# Altium schematic sheet LED.SchDoc(LED)
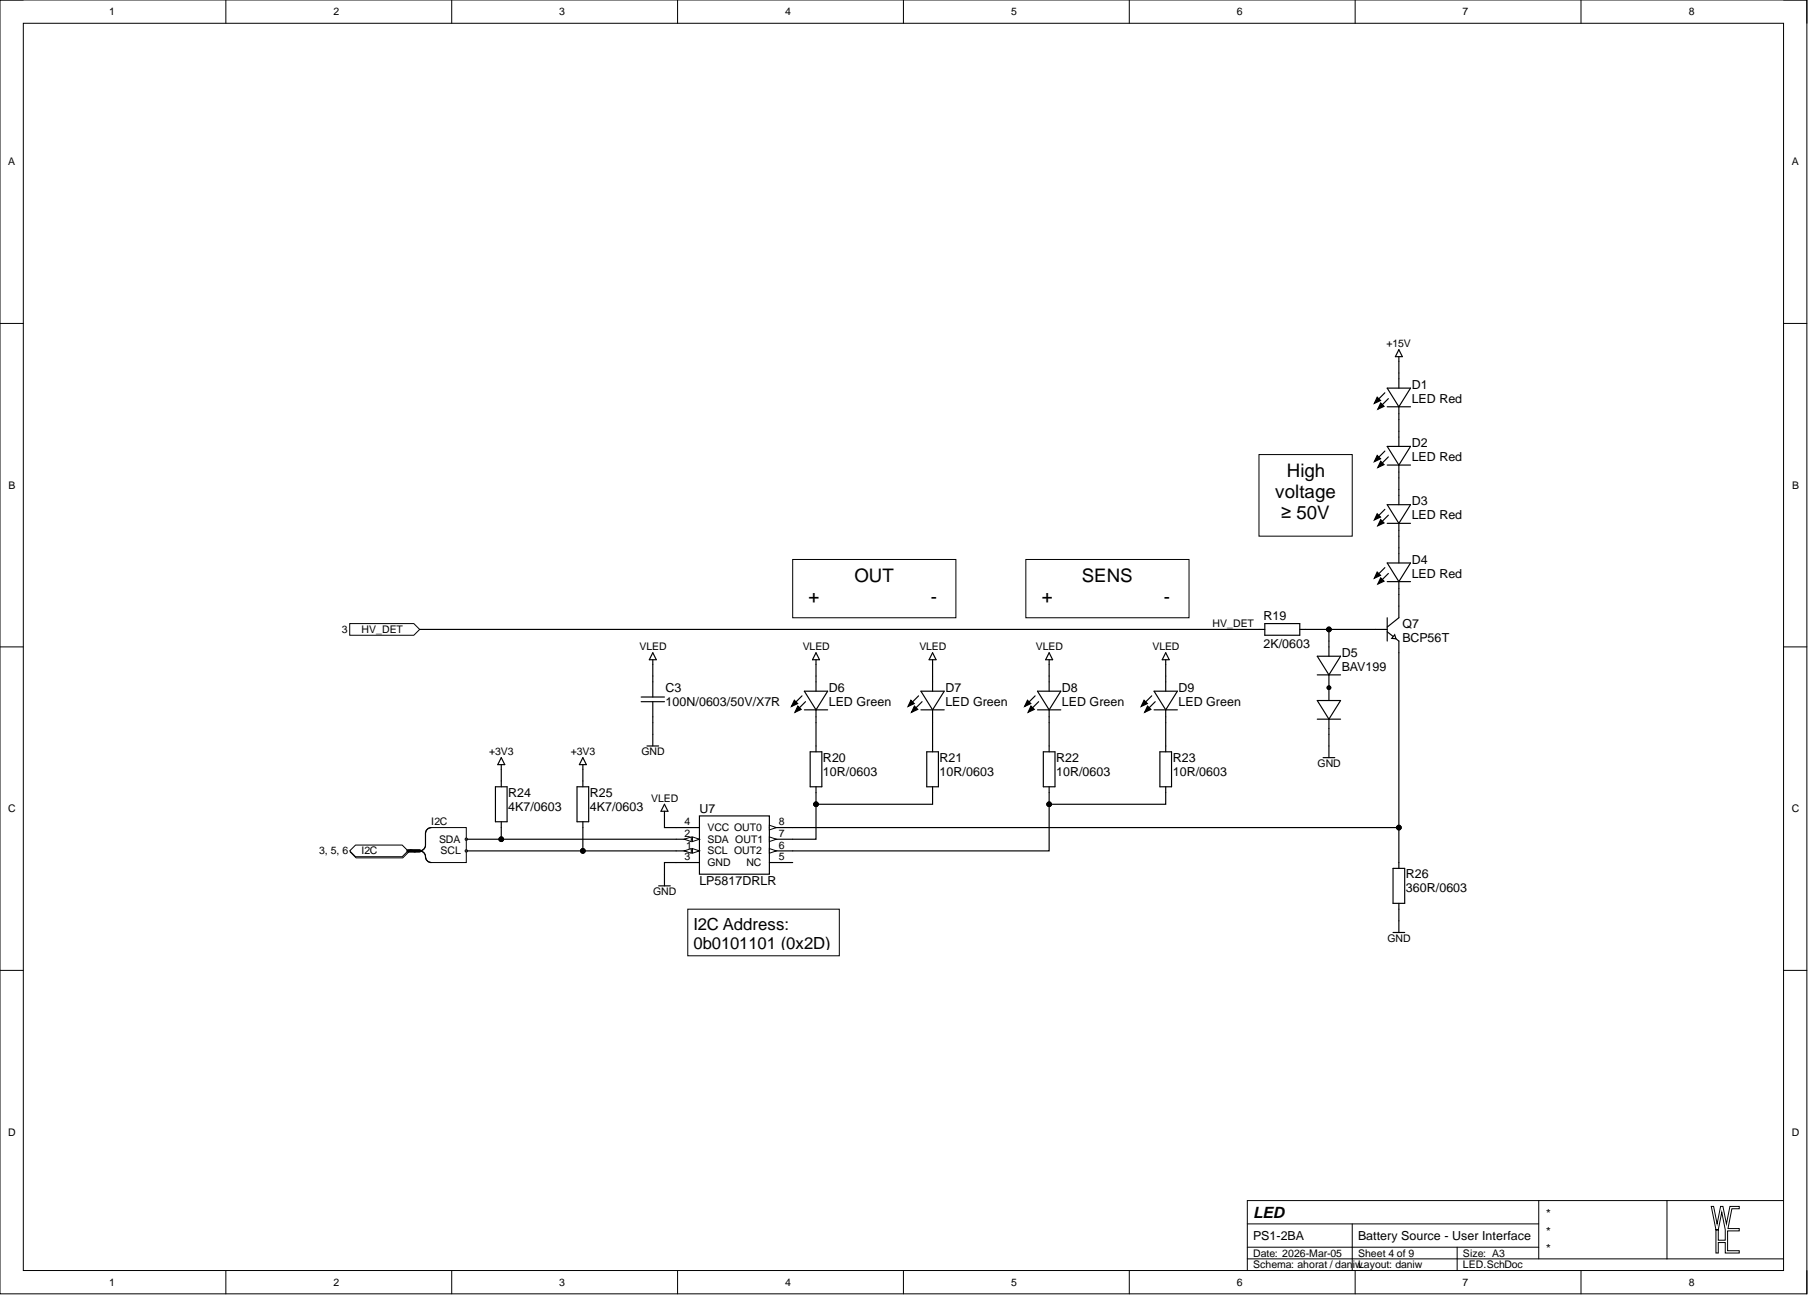
[diagram p0: LED.SchDoc]

## Parts
C3  100N/0603/50V/X7R  [CAP0603_X7R]
D1  LED Red  value Red  Absolute Value: Red  [LED0805_RED]
D2  LED Red  value Red  Absolute Value: Red  [LED0805_RED]
D3  LED Red  value Red  Absolute Value: Red  [LED0805_RED]
D4  LED Red  value Red  Absolute Value: Red  [LED0805_RED]
D5  BAV199  [SOT2395P280X125-3-SE]
D6  LED Green  [LED0805_GREEN]
D7  LED Green  value Green  Absolute Value: Green  [LED0805_GREEN]
D8  LED Green  value Green  Absolute Value: Green  [LED0805_GREEN]
D9  LED Green  value Green  Absolute Value: Green  [LED0805_GREEN]
Q7  BCP56T  [SOT223-230P670X180-4-SW]
R19  2K/0603  [R0603-0.40-WW]
R20  10R/0603  [R0603-0.40-WW]
R21  10R/0603  [R0603-0.40-WW]
R22  10R/0603  [R0603-0.40-WW]
R23  10R/0603  [R0603-0.40-WW]
R24  4K7/0603  [R0603-0.40-WW]
R25  4K7/0603  [R0603-0.40-WW]
R26  360R/0603  [R0603-0.40-WW]
U7  LP5817DRLR  [SOT50P210X60-8-SE]

## Ports
HV_DET, I2C, I2C.SCL, I2C.SDA

## Nets
+3V3: R24.1 R25.1
+15V: D1.2
GND: C3.2 D5.2 R26.2 U7.3(GND)
VLED: C3.1 D6.2 D7.2 D8.2 D9.2 U7.4(VCC)
HV_DET: R19.1 ; port HV_DET
NetD1_1: D1.1 D2.2
NetD2_1: D2.1 D3.2
NetD3_1: D3.1 D4.2
NetD4_1: D4.1 Q7.2,4
NetD5_1: D5.1 Q7.1 R19.2
NetD5_3: D5.3
NetD6_1: D6.1 R20.1
NetD7_1: D7.1 R21.1
NetD8_1: D8.1 R22.1
NetD9_1: D9.1 R23.1
NetQ7_3: Q7.3 R26.1 U7.8(OUT0)
NetR20_2: R20.2 R21.2 U7.7(OUT1)
NetR22_2: R22.2 R23.2 U7.6(OUT2)
NetR24_2: R24.2 U7.2(SDA) ; port I2C
NetR25_2: R25.2 U7.1(SCL) ; port I2C
NetU7_5: U7.5(NC)

## Library data of the parts
C3 = 100N/0603/50V/X7R: CAP SM 0603 100nF 50V X7R; Manufacturer: GENERIC; Manufacturer 1: YAGEO; Manufacturer Part Number 1: CC0603KPX7R9BB104; Absolute Value: 1E-7; Database Table Name: C$; Eurocircuits GPN: GPC0603104; Component Kind: Standard; HelpURL: https://www.yageo.com/upload/media/product/app/datasheet/mlcc/upy-gphc_x7r_6_3v-to-250v.pdf; JLC Type: Basic; Manufacturer 2: YAGEO; Manufacturer Part Number 2: CC0603MRX7R9BB104; Manufacturer Part Number 3: CC0603KRX7R9BB104; Package: 0603; Part Number: 100N/0603/50V/X7R; Supplier 1: Digi-Key; Supplier 2: Digi-Key; Supplier 3: LCSC; Supplier Part Number 1: 311-3567-1-ND; Supplier Part Number 2: 311-4110-1-ND; Supplier Part Number 3: C14663; Value: 100nF; Library Name: daniw_altium_lib.DbLib
D1-D4 = LED Red 0805: Generic LED Red 0805; Manufacturer 1: Würth; Manufacturer Part Number 1: 150080RS75000; Database Table Name: D$; Component Kind: Standard; HelpURL: https://www.we-online.com/katalog/datasheet/150060RS75000.pdf; JLC Type: Basic; Manufacturer: GENERIC; Manufacturer 3: Foshan NationStar Optoelectronics; Manufacturer Part Number 3: NCD0805R1; Package: 805; Part Number: LED Red 0805; Supplier 1: Digikey; Supplier 3: LCSC; Supplier Part Number 1: 732-4984-1-ND; Supplier Part Number 3: C84256; Library Name: daniw_altium_lib.DbLib
D5 = BAV199: Silicon Low Leakage Diode 85V; Manufacturer: Infineon Technologies; Manufacturer Part Number 1: BAV199E6433HTMA1; Database Table Name: D$; Absolute Value: 85V; Component Kind: Standard; HelpURL: https://www.infineon.com/dgdl/bav199series.pdf?folderId=db3a30431400ef6801141c748874044e&fileId=db3a30431400ef6801141cba733104e5; Manufacturer 1: Infineon Technologies; Manufacturer 3: LRC; Manufacturer Part Number 3: LBAV199LT1G; Package: SOT23; Part Number: BAV199; Supplier 1: Digikey; Supplier 3: LCSC; Supplier Part Number 1: BAV199E6433HTMA1CT-ND; Supplier Part Number 3: C12740; Value: BAV199; Library Name: daniw_altium_lib.DbLib
D6-D9 = LED Green 0805: Generic LED Green 0805; Manufacturer 1: Würth; Manufacturer Part Number 1: 150080GS75000; Database Table Name: D$; Component Kind: Standard; HelpURL: https://www.we-online.com/katalog/datasheet/150060VS75000.pdf; JLC Type: Basic; Manufacturer: GENERIC; Manufacturer 3: Hubei KENTO Elec; Manufacturer Part Number 3: KT-0805G; Package: 805; Part Number: LED Green 0805; Supplier 1: Digikey; Supplier 3: LCSC; Supplier Part Number 1: 732-4983-1-ND; Supplier Part Number 3: C2297; Library Name: daniw_altium_lib.DbLib
  D6: Absolute Value: Green; Value: Green
Q7 = BCP56T: BCP56T 80 V, 1 A NPN medium power transistor; Manufacturer: Nexperia USA Inc.; Manufacturer Part Number 1: BCP56TF; Database Table Name: T$; Component Kind: Standard; HelpURL: https://assets.nexperia.com/documents/data-sheet/BCP56T_SER.pdf; Manufacturer 1: Nexperia USA Inc.; Manufacturer 3: Nexperia; Manufacturer Part Number 3: BCP56,115; Package: SOT223-4; Part Number: BCP56T; Supplier 1: Digi-Key; Supplier 3: LCSC; Supplier Part Number 1: 1727-7619-1-ND; Supplier Part Number 3: C22239; Library Name: daniw_altium_lib.DbLib
R19 = 2K/0603: RES SM 0603 2K; Manufacturer: GENERIC; Manufacturer 1: YAGEO; Manufacturer Part Number 1: RC0603FR-132KL; Absolute Value: 2000; Database Table Name: R$; Eurocircuits GPN: GPR06032K; Component Kind: Standard; HelpURL: https://www.yageogroup.com/content/datasheet/asset/file/PYU-RC_GROUP_51_ROHS_L; JLC Type: Basic; Manufacturer 2: YAGEO; Manufacturer 3: UNI-ROYAL(Uniroyal Elec); Manufacturer Part Number 2: RC0603FR-102KL; Manufacturer Part Number 3: 0603WAF2001T5E; Package: 0603; Part Number: 2K/0603; Supplier 1: Digi-Key; Supplier 2: Digi-Key; Supplier 3: LCSC; Supplier Part Number 1: 13-RC0603FR-132KLCT-ND; Supplier Part Number 2: 13-RC0603FR-102KLCT-ND; Supplier Part Number 3: C22975; Value: 2K; Library Name: daniw_altium_lib.DbLib
R20-R23 = 10R/0603: RES SM 0603 10R; Manufacturer: GENERIC; Manufacturer 1: YAGEO; Manufacturer Part Number 1: RC0603FR-1310RL; Absolute Value: 10; Database Table Name: R$; Eurocircuits GPN: GPR060310R; Component Kind: Standard; HelpURL: https://www.yageogroup.com/content/datasheet/asset/file/PYU-RC_GROUP_51_ROHS_L; JLC Type: Basic; Manufacturer 2: YAGEO; Manufacturer 3: UNI-ROYAL; Manufacturer Part Number 2: RC0603FR-1010RL; Manufacturer Part Number 3: 0603WAF100JT5E; Package: 0603; Part Number: 10R/0603; Supplier 1: Digi-Key; Supplier 2: Digi-Key; Supplier 3: LCSC; Supplier Part Number 1: 13-RC0603FR-1310RLCT-ND; Supplier Part Number 2: 13-RC0603FR-1010RLCT-ND; Supplier Part Number 3: C22859; Value: 10R; Library Name: daniw_altium_lib.DbLib
R24 R25 = 4K7/0603: RES SM 0603 4K7; Manufacturer: GENERIC; Manufacturer 1: YAGEO; Manufacturer Part Number 1: RC0603FR-134K7L; Absolute Value: 4700; Database Table Name: R$; Eurocircuits GPN: GPR06034K7; Component Kind: Standard; HelpURL: https://www.yageogroup.com/content/datasheet/asset/file/PYU-RC_GROUP_51_ROHS_L; JLC Type: Basic; Manufacturer 2: YAGEO; Manufacturer 3: UNI-ROYAL(Uniroyal Elec); Manufacturer Part Number 2: RC0603FR-104K7L; Manufacturer Part Number 3: 0603WAF4701T5E; Package: 0603; Part Number: 4K7/0603; Supplier 1: Digi-Key; Supplier 2: Digi-Key; Supplier 3: LCSC; Supplier Part Number 1: 13-RC0603FR-134K7LCT-ND; Supplier Part Number 2: 13-RC0603FR-104K7LCT-ND; Supplier Part Number 3: C23162; Value: 4K7; Library Name: daniw_altium_lib.DbLib
R26 = 360R/0603: RES SM 0603 360R; Manufacturer: GENERIC; Manufacturer 1: YAGEO; Manufacturer Part Number 1: RC0603FR-13360RL; Absolute Value: 360; Database Table Name: R$; Eurocircuits GPN: GPR0603360R; Component Kind: Standard; HelpURL: https://www.yageogroup.com/content/datasheet/asset/file/PYU-RC_GROUP_51_ROHS_L; JLC Type: Extended; Manufacturer 2: YAGEO; Manufacturer 3: YAGEO; Manufacturer Part Number 2: RC0603FR-07360RL; Manufacturer Part Number 3: RC0603FR-07360RL; Package: 0603; Part Number: 360R/0603; Supplier 1: Digi-Key; Supplier 2: Digi-Key; Supplier 3: LCSC; Supplier Part Number 1: 13-RC0603FR-13360RLCT-ND; Supplier Part Number 2: 311-360HRCT-ND; Supplier Part Number 3: C137739; Value: 360R; Library Name: daniw_altium_lib.DbLib
U7 = LP5817DRLR: LP5817 3-Channel I2C Interface RGB LED Driver; Manufacturer: Texas Instruments; Database Table Name: Integrated$; Component Kind: Standard; HelpURL: https://www.ti.com/lit/ds/symlink/lp5817.pdf; JLC Type: Extended; Manufacturer 1: Texas Instruments; Manufacturer 3: TI; Manufacturer Part Number 1: LP5817DRLR; Manufacturer Part Number 3: LP5817DRLR; Package: SOT586; Part Number: LP5817DRLR; Supplier 1: Digi-Key; Supplier 3: LCSC; Supplier Part Number 1: 296-LP5817DRLRCT-ND; Supplier Part Number 3: C47262829; Library Name: daniw_altium_lib.DbLib
